# Altium schematic sheet bminator.SchDoc
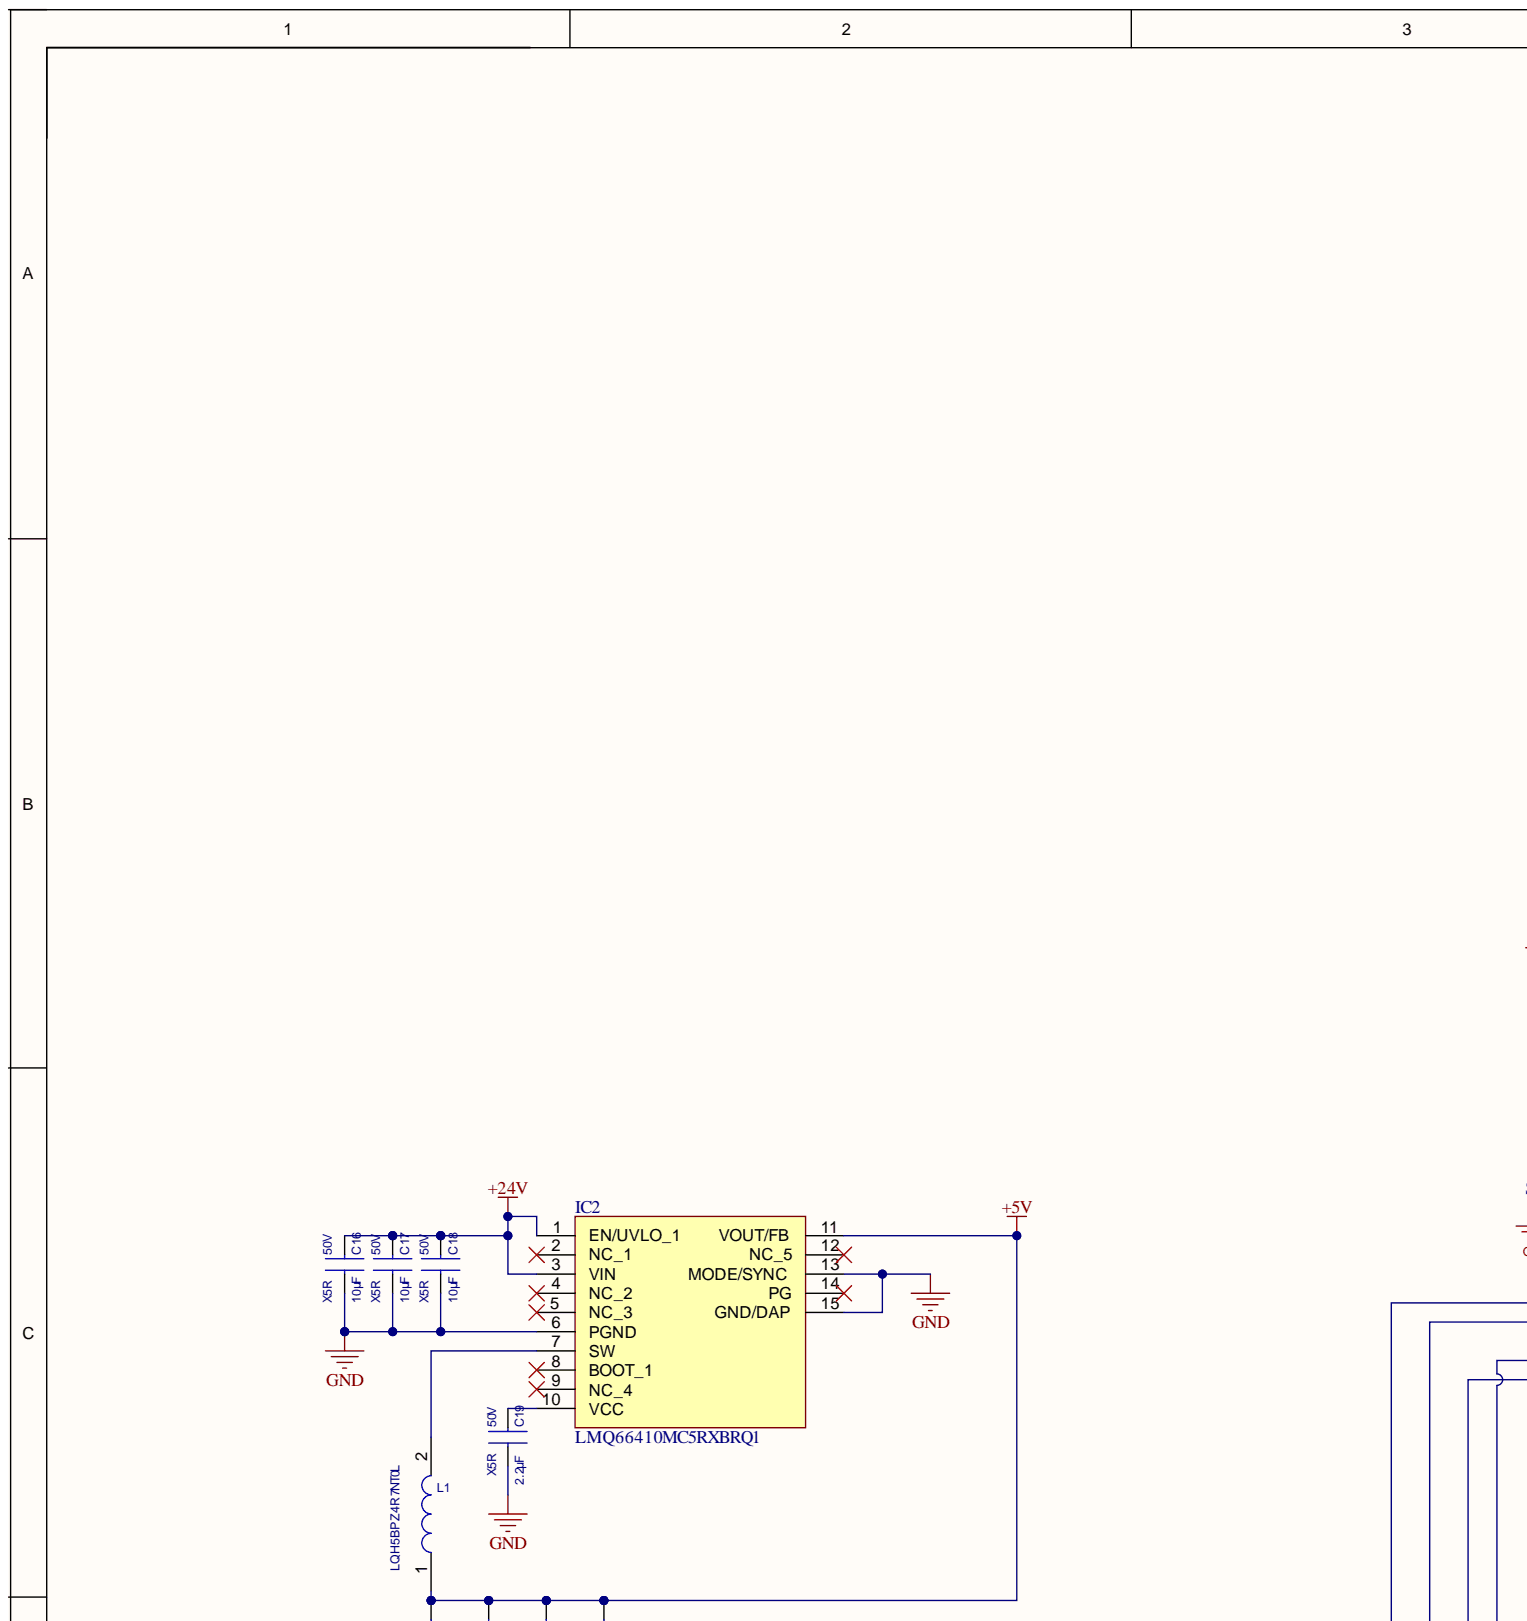
[diagram p0: bminator.SchDoc, top left]
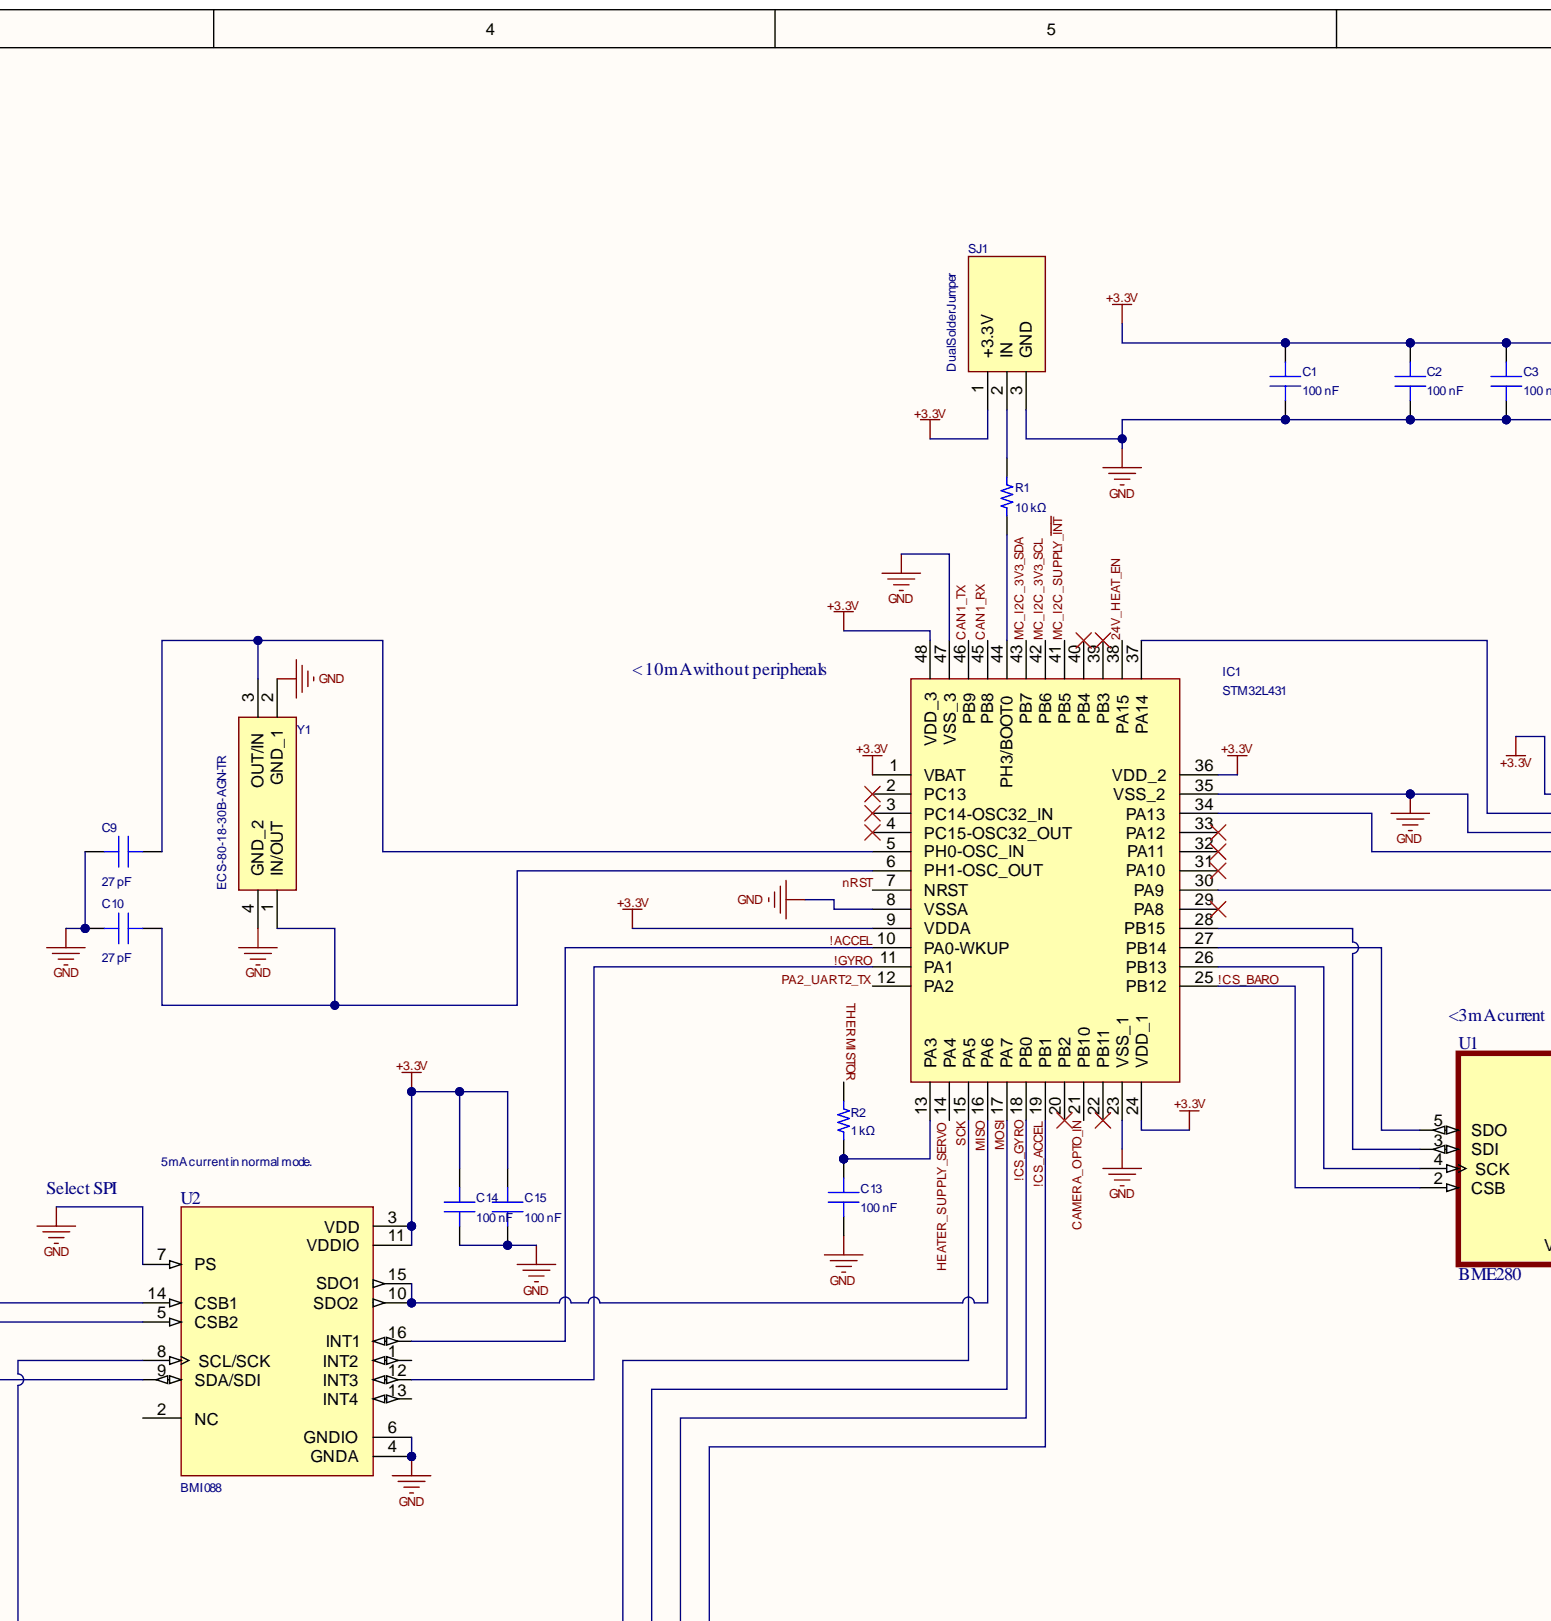
[diagram p1: bminator.SchDoc, top centre]
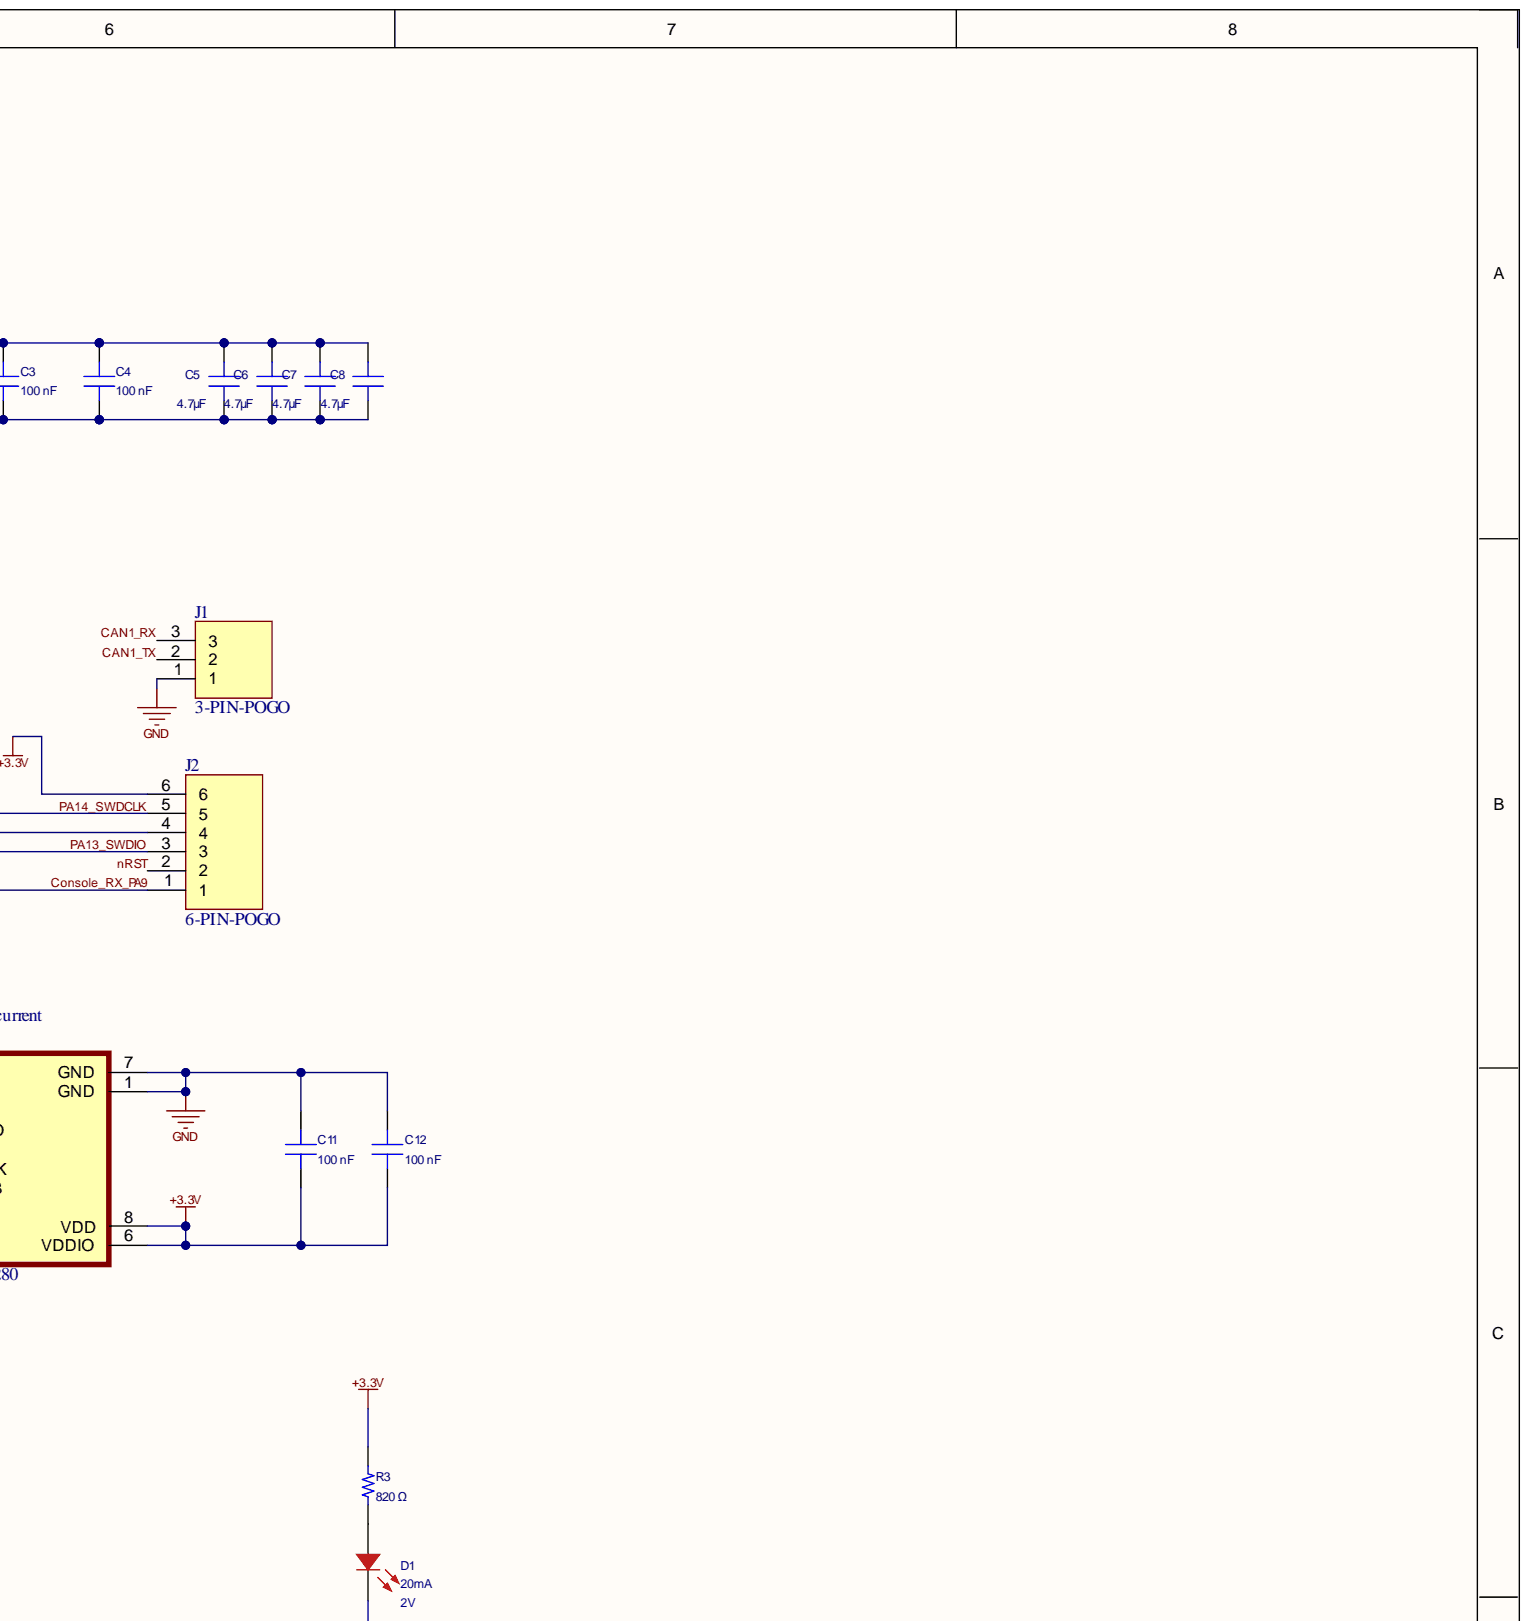
[diagram p2: bminator.SchDoc, top right]
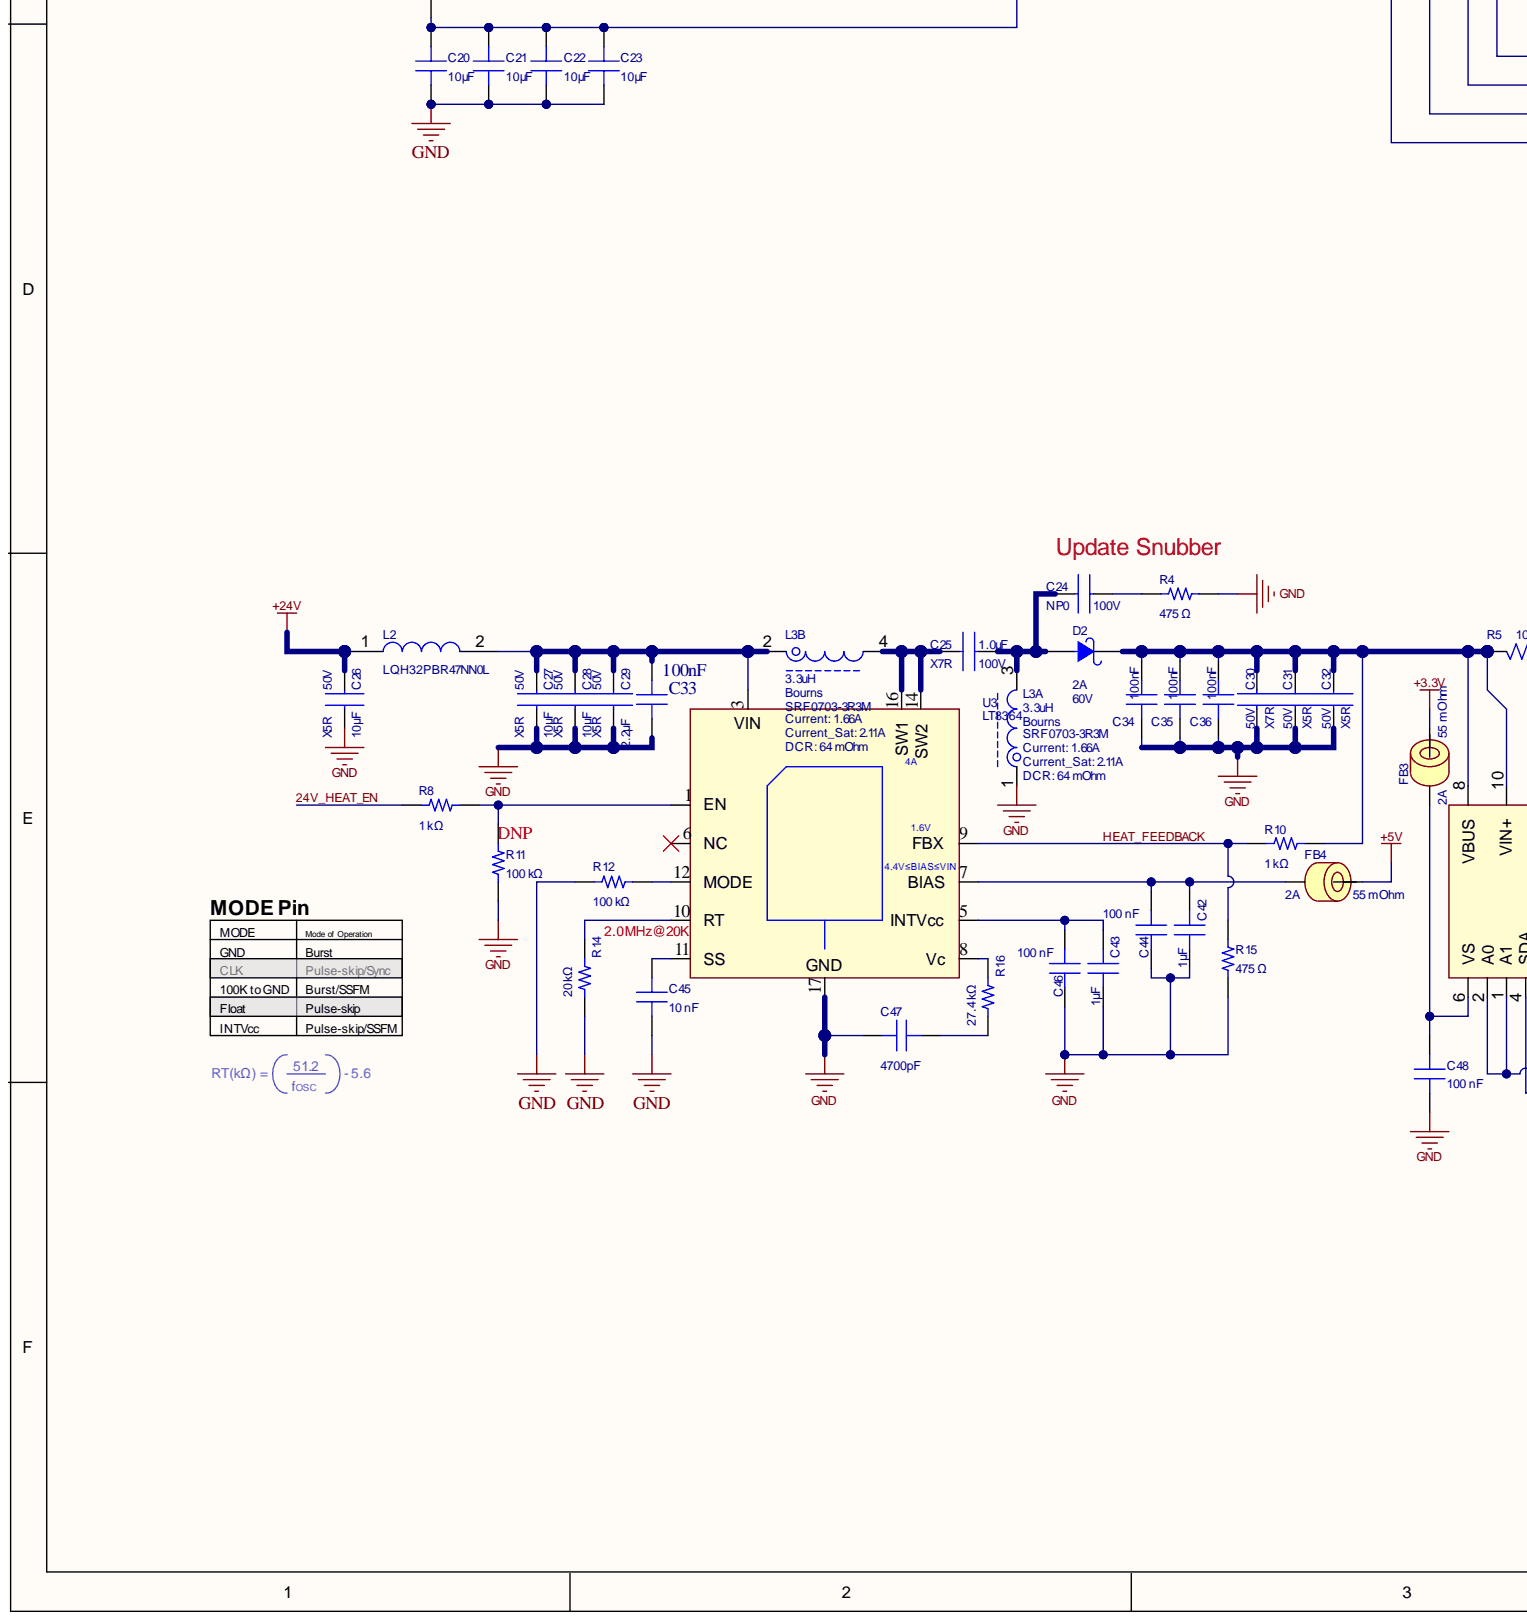
[diagram p3: bminator.SchDoc, bottom left]
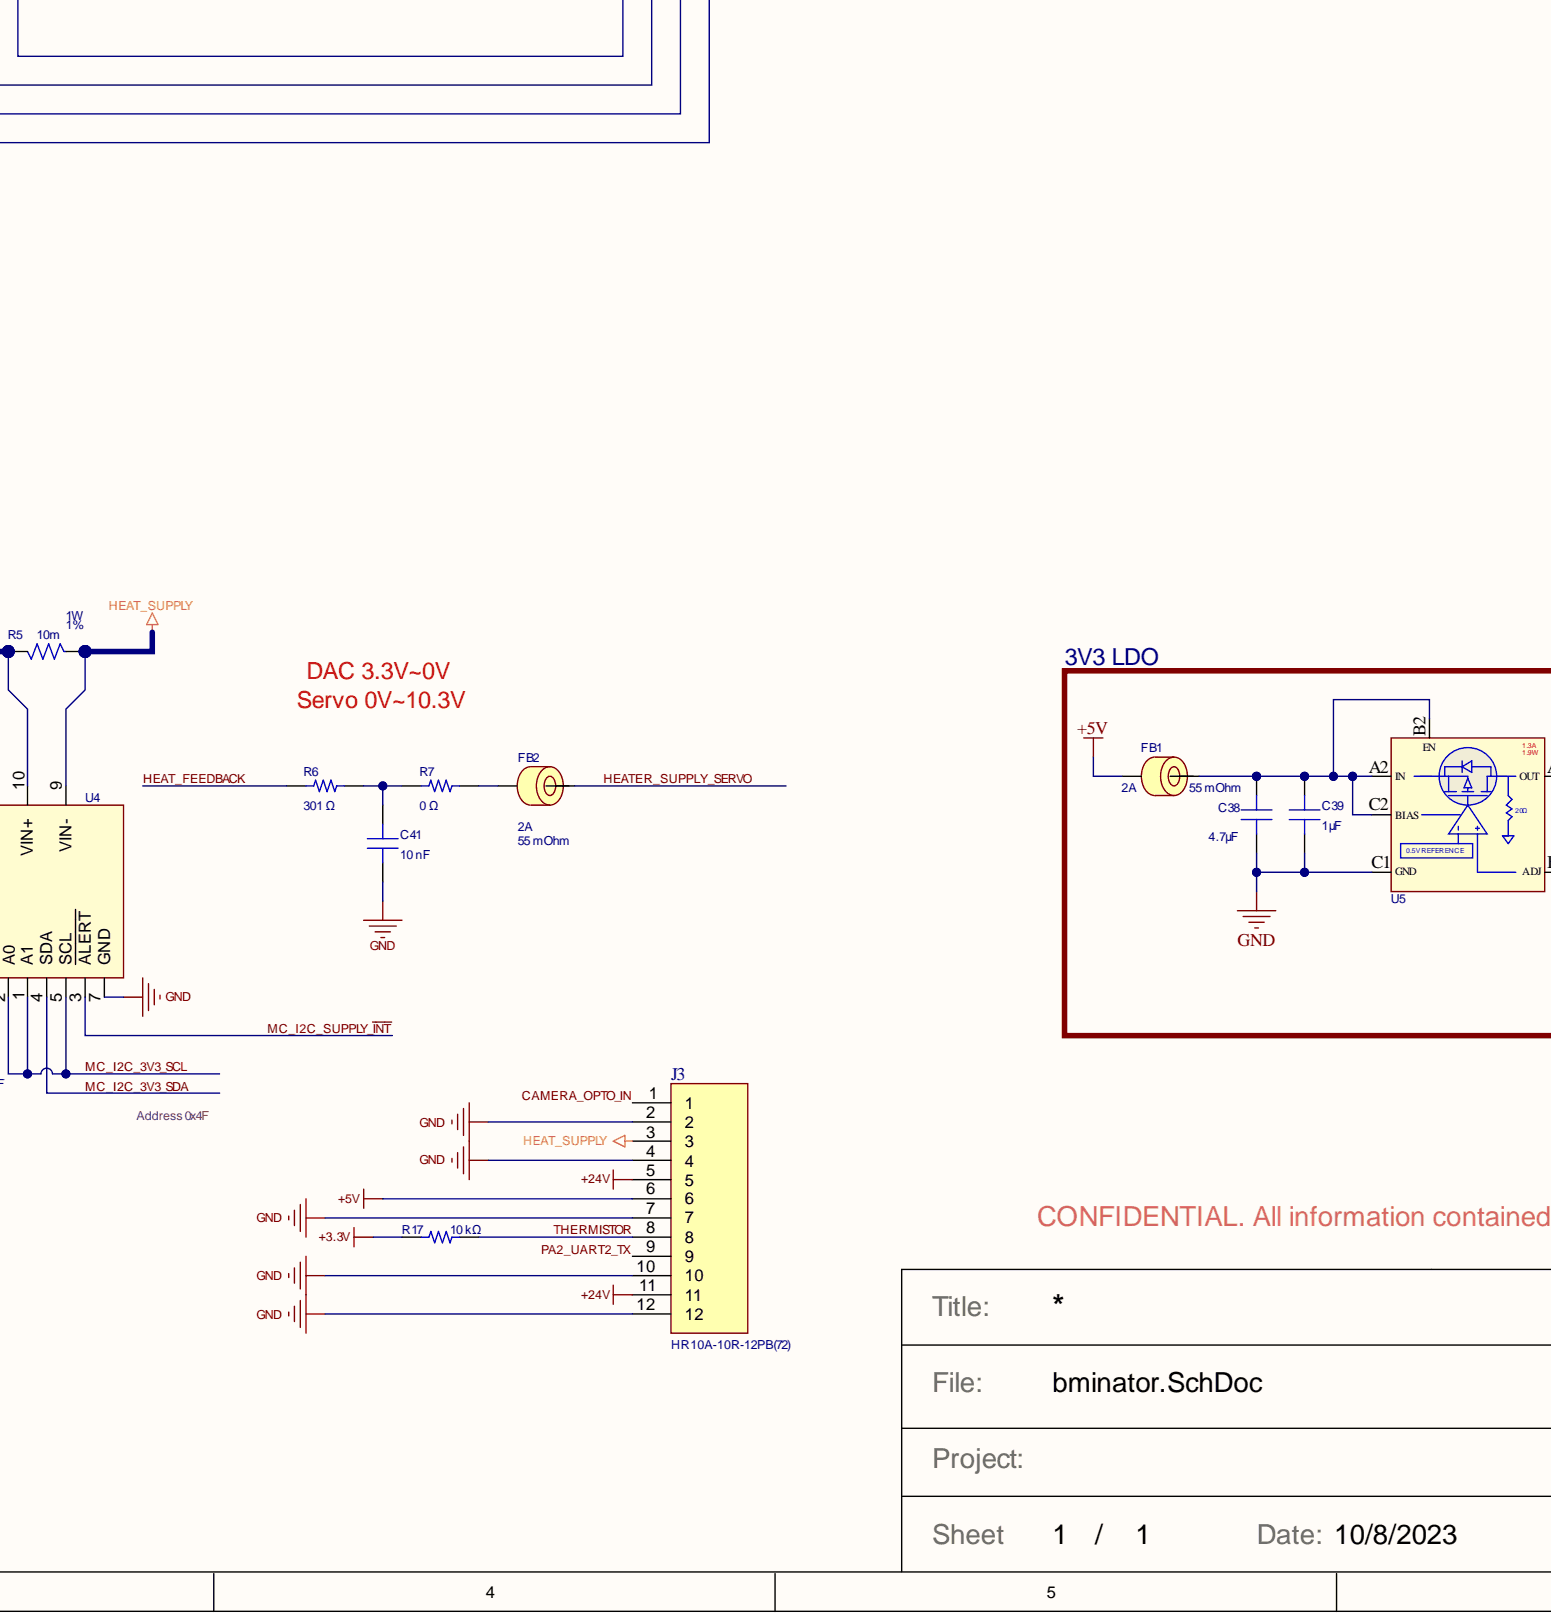
[diagram p4: bminator.SchDoc, bottom centre]
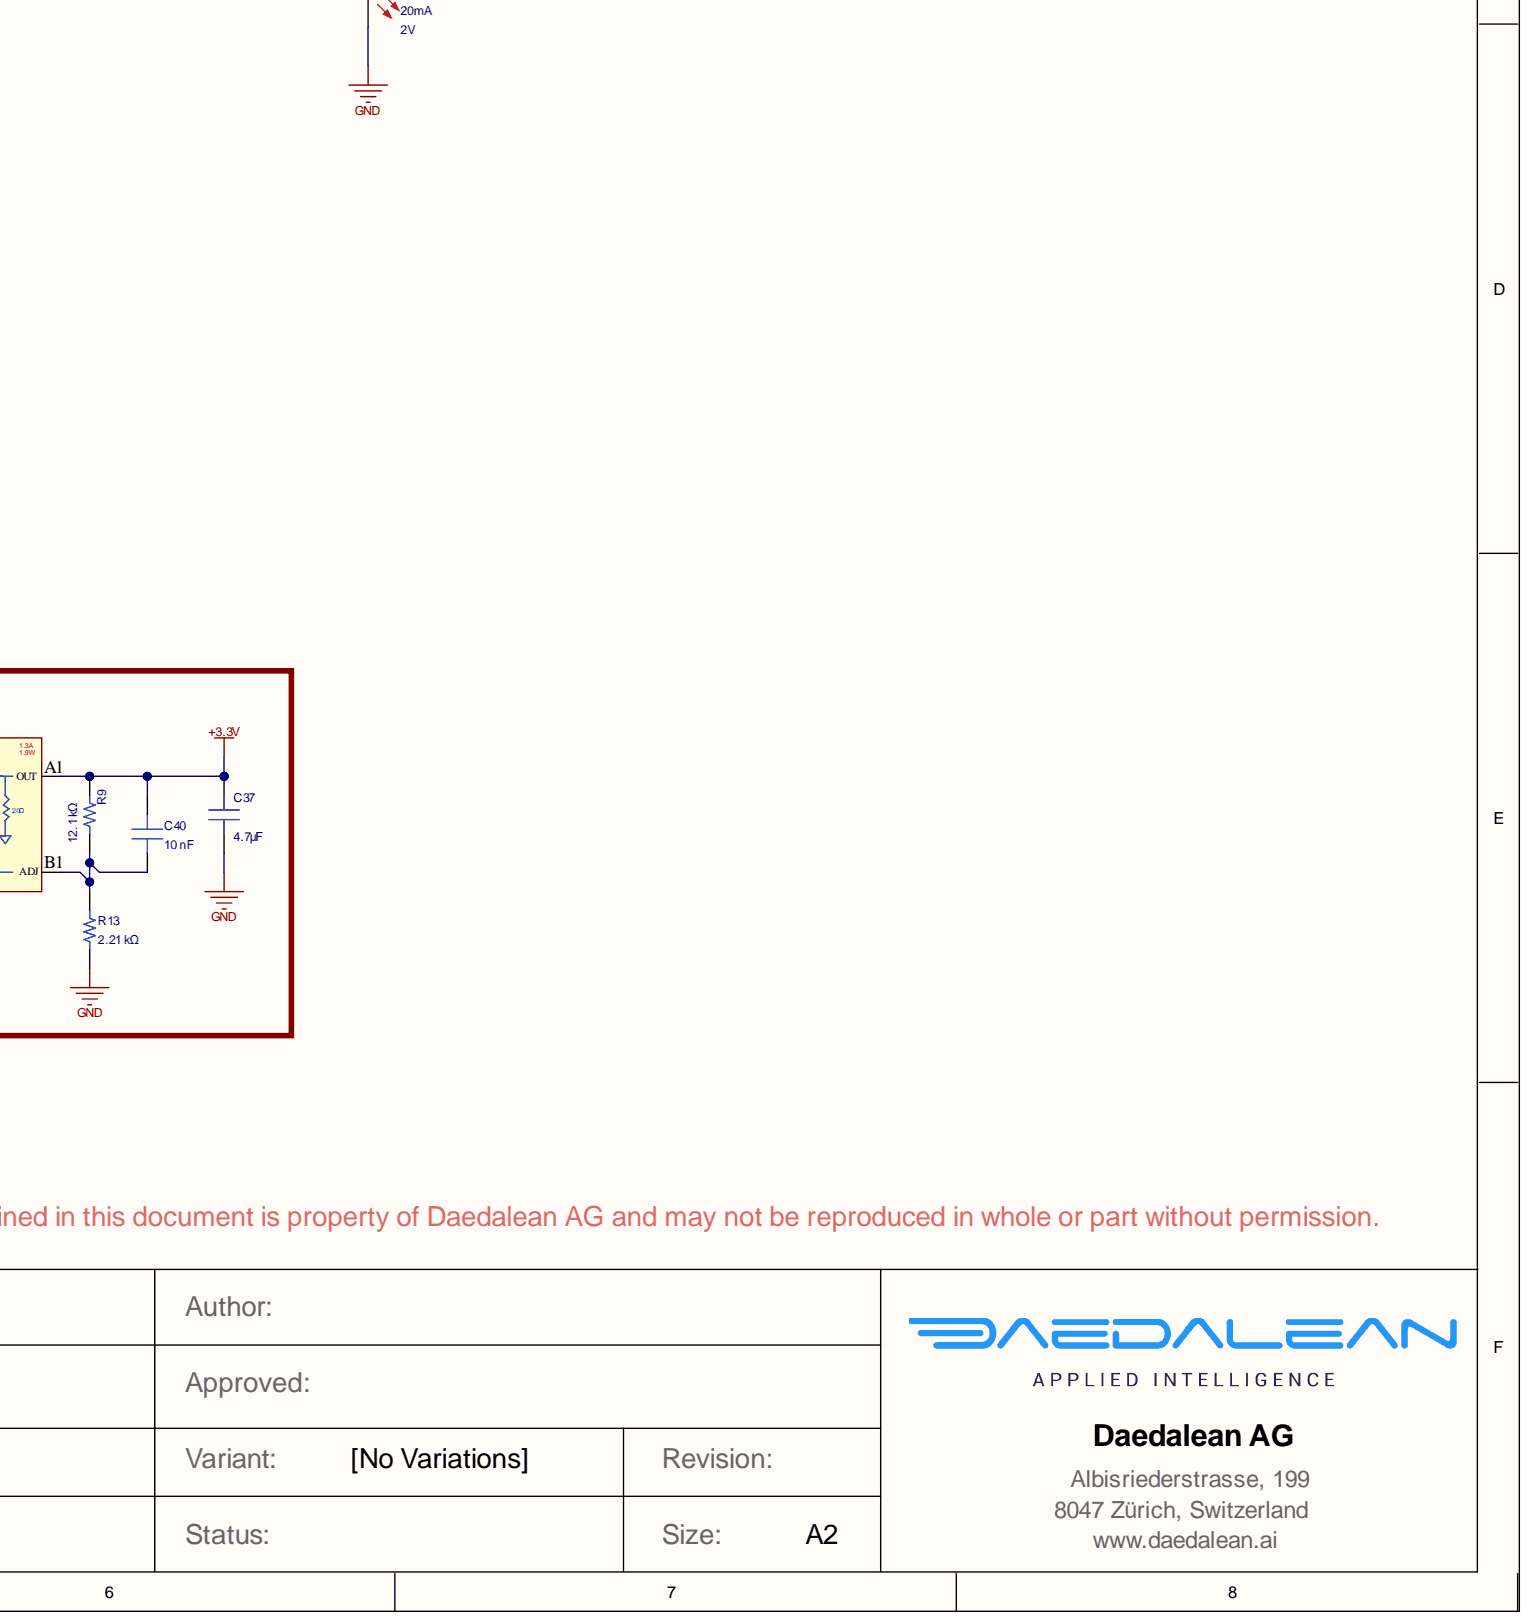
[diagram p5: bminator.SchDoc, bottom right]

## Parts
C1  value 100 nF  [CAP 0201_0603]  (hidden comment: GRT033C71C104KE01D)
C2  value 100 nF  [CAP 0201_0603]  (hidden comment: GRT033C71C104KE01D)
C3  value 100 nF  [CAP 0201_0603]  (hidden comment: GRT033C71C104KE01D)
C4  value 100 nF  [CAP 0201_0603]  (hidden comment: GRT033C71C104KE01D)
C5  4.7µF  [CAP 0402_1005]
C6  4.7µF  [CAP 0402_1005]
C7  4.7µF  [CAP 0402_1005]
C8  4.7µF  [CAP 0402_1005]
C9  value 27 pF  [CAP 0201_0603]  (hidden comment: GRT0335C1E270JA02D)
C10  value 27 pF  [CAP 0201_0603]  (hidden comment: GRT0335C1E270JA02D)
C11  value 100 nF  [CAP 0201_0603]  (hidden comment: GRT033C71C104KE01D)
C12  value 100 nF  [CAP 0201_0603]  (hidden comment: GRT033C71C104KE01D)
C13  value 100 nF  [CAP 0201_0603]  (hidden comment: GRT033C71C104KE01D)
C14  value 100 nF  [CAP 0201_0603]  (hidden comment: GRT033C71C104KE01D)
C15  value 100 nF  [CAP 0201_0603]  (hidden comment: GRT033C71C104KE01D)
C16  10µF  DIELECTRIC: X5R  VOLTAGE: 50V  [CAPC2013X140N]
C17  10µF  DIELECTRIC: X5R  VOLTAGE: 50V  [CAPC2013X140N]
C18  10µF  DIELECTRIC: X5R  VOLTAGE: 50V  [CAPC2013X140N]
C19  2.2µF  DIELECTRIC: X5R  VOLTAGE: 50V  [CAPC1608X95N]
C20  value 10µF  [CAP 0603_1608]  (hidden comment: GRT188R61C106KE13D)
C21  value 10µF  [CAP 0603_1608]  (hidden comment: GRT188R61C106KE13D)
C22  value 10µF  [CAP 0603_1608]  (hidden comment: GRT188R61C106KE13D)
C23  value 10µF  [CAP 0603_1608]  (hidden comment: GRT188R61C106KE13D)
C24  DIELECTRIC: NP0  VOLTAGE: 100V  [CAPC1608X95N]
C25  1.0µF  DIELECTRIC: X7R  VOLTAGE: 100V  [CAPC2013X140N]
C26  10µF  DIELECTRIC: X5R  VOLTAGE: 50V  [CAPC2013X140N]
C27  10µF  DIELECTRIC: X5R  VOLTAGE: 50V  [CAPC2013X140N]
C28  10µF  DIELECTRIC: X5R  VOLTAGE: 50V  [CAPC2013X140N]
C29  2.2µF  DIELECTRIC: X5R  VOLTAGE: 50V  [CAPC1608X95N]
C30  DIELECTRIC: X7R  VOLTAGE: 50V  [CAPC3225X279N]
C31  DIELECTRIC: X5R  VOLTAGE: 50V  [CAPC2013X140N]
C32  DIELECTRIC: X5R  VOLTAGE: 50V  [CAPC2013X140N]
C33  100nF  [CAP 0402_1005]
C34  100nF  [CAP 0402_1005]
C35  100nF  [CAP 0402_1005]
C36  100nF  Voltage - Rated: 50V  [CAP 0402_1005]
C37  4.7µF  [CAP 0402_1005]
C38  4.7µF  [CAP 0402_1005]
C39  1µF  [CAP 0402_1005]
C40  value 10 nF  [CAP 0201_0603]  (hidden comment: 02013C103MAT2A)
C41  value 10 nF  [CAP 0201_0603]  (hidden comment: 02013C103MAT2A)
C42  1µF  [CAP 0402_1005]
C43  1µF  [CAP 0402_1005]
C44  value 100 nF  [CAP 0201_0603]  (hidden comment: GRT033C71C104KE01D)
C45  value 10 nF  [CAP 0201_0603]  (hidden comment: 02013C103MAT2A)
C46  value 100 nF  [CAP 0201_0603]  (hidden comment: GRT033C71C104KE01D)
C47  4700pF  [CAP 0402_1005]
C48  value 100 nF  [CAP 0201_0603]  (hidden comment: GRT033C71C104KE01D)
D1  Forward Voltage: 2V  Test Current: 20mA  [LED 0402 RED]  (hidden comment: VLMS1500-GS08)
D2  Current: 2A  Voltage: 60V  [SODFL3517X110N]
FB1  Current: 2A  DCR: 55 mOhm  [TDK_MPZ1005-H]
FB2  Current: 2A  DCR: 55 mOhm  [TDK_MPZ1005-H]
FB3  Current: 2A  DCR: 55 mOhm  [TDK_MPZ1005-H]
FB4  Current: 2A  DCR: 55 mOhm  [TDK_MPZ1005-H]
IC1  STM32L431  [QFP50P900X900X160-48N]
IC2  LMQ66410MC5RXBRQ1  [LMQ66430R5RXBR]
J1  3-PIN-POGO  [3PINPOGO]
J2  6-PIN-POGO  [6PINPOGO]
J3  HR10A-10R-12PB(72)  [HRS_HR10A-10R-12PB(72)]
L1  LQH5BPZ4R7NT0L  [LQH5BPB100MT0L]
L2  LQH32PBR47NN0L  [LQH32CH100K53L]
L3  3.3uH  DCR: 64 mOhm  Manufacturer: Bourns  Manufacturer P/N: SRF0703-3R3M  [BOURNS_SRF0703]  (parts A,B)
R1  10 kΩ  [RES 0201_0603]
R2  1 kΩ  [RES 0201_0603]
R3  820 Ω  [RES 0402_1005]
R4  475 Ω  [RES 0402_1005]
R5  value 10m  TOLERANCE: 1%  Wattage: 1W  [RESC3216X84N]
R6  301 Ω  [RES 0402_1005]
R7  0 Ω  [RES 0402_1005]
R8  1 kΩ  [RES 0201_0603]
R9  12.1 kΩ  [RES 0201_0603]
R10  1 kΩ  [RES 0402_1005]
R11  100 kΩ  Resistance: DNP  [RES 0201_0603]
R12  100 kΩ  [RES 0201_0603]
R13  2.21 kΩ  [RES 0201_0603]
R14  20 kΩ  [RES 0201_0603]
R15  475 Ω  [RES 0402_1005]
R16  27.4 kΩ  [RES 0201_0603]
R17  10 kΩ  [RES 0201_0603]
SJ1  DualSolderJumper  [DualSolderJumper]
U1  BME280  [PSON65P250X250X100-8N]
U2  BMI088  [PQFN50P450X300X100-16N]
U3  LT8364  Current: 4A  [SOP50P490X110-13N]
U4  (no value)  [SOP50P490X110-10N]
U5  Current: 1.3A  Wattage: 1.9W  [BGA8C40P2X3_80X120X33]
Y1  ECS-80-18-30B-AGN-TR  [ECS801830BAGNTR]

## Nets
+3.3V: C1.2 C2.2 C3.2 C4.2 C5.2 C6.2 C7.2 C8.2 C11.1 C12.1 C14.2 C15.2 C37.2 C40.2 FB3.1 IC1.1(VBAT) IC1.9(VDDA) IC1.24(VDD_1) IC1.36(VDD_2) IC1.48(VDD_3) J2.6 R3.1 R9.2 R17.2 SJ1.1 U1.6(VDDIO) U1.8(VDD) U2.3(VDD) U2.11(VDDIO) U5.A1(OUT)
+5V: C20.2 C21.2 C22.2 C23.2 FB1.2 FB4.1 IC2.11(VOUT/FB) J3.6 L1.1
GND: C1.1 C2.1 C3.1 C4.1 C5.1 C6.1 C7.1 C8.1 C9.2 C10.2 C11.2 C12.2 C13.1 C14.1 C15.1 C16.2 C17.2 C18.2 C19.2 C20.1 C21.1 C22.1 C23.1 C26.2 C27.2 C28.2 C29.2 C30.2 C31.2 C32.2 C33.1 C34.1 C35.1 C36.1 C37.1 C38.1 C39.1 C41.1 C42.1 C43.1 C44.1 C45.1 C46.1 C47.1 C48.1 D1.K IC1.8(VSSA) IC1.23(VSS_1) IC1.35(VSS_2) IC1.47(VSS_3) IC2.6(PGND) IC2.13(MODE/SYNC) IC2.15(GND/DAP) J1.1 J2.4 J3.2 J3.4 J3.7 J3.10 J3.12 L3.1 R4.1 R11.1 R12.1 R13.1 R14.1 R15.1 SJ1.3 U1.1(GND) U1.7(GND) U2.4(GNDA) U2.6(GNDIO) U2.7(PS) U3.17 U4.7(GND) U5.C1(GND) Y1.2(GND_1) Y1.4(GND_2)
HEAT_SUPPLY: J3.3 R5.1 U4.9(VIN-)
24V_HEAT_EN: C16.1 C17.1 C18.1 C26.1 IC1.38(PA15) IC2.1(EN/UVLO_1) IC2.3(VIN) J3.5 J3.11 L2.1 R8.1
!ACCEL: IC1.10(PA0-WKUP) U2.16(INT1)
!CS_ACCEL: IC1.19(PB1) U2.14(CSB1)
!CS_BARO: IC1.25(PB12) U1.2(CSB)
!CS_GYRO: IC1.18(PB0) U2.5(CSB2)
!GYRO: IC1.11(PA1) U2.12(INT3)
CAMERA_OPTO_IN: IC1.21(PB10) J3.1
CAN1_RX: IC1.45(PB8) J1.3
CAN1_TX: IC1.46(PB9) J1.2
Console_RX_PA9: IC1.30(PA9) J2.1
HEAT_FEEDBACK: R6.1 R10.1 R15.2 U3.9(FBX)
HEATER_SUPPLY_SERVO: FB2.1 IC1.14(PA4)
MC_I2C_3V3_SCL: IC1.42(PB6) U4.1(A1) U4.2(A0) U4.5(SCL)
MC_I2C_3V3_SDA: IC1.43(PB7) U4.4(SDA)
MC_I2C_SUPPLY_~{INT}: IC1.41(PB5) U4.3(ALERT)
MISO: IC1.16(PA6) U2.10(SDO2) U2.15(SDO1)
MOSI: IC1.17(PA7) U2.9(SDA/SDI)
nRST: IC1.7(NRST) J2.2
PA2_UART2_TX: IC1.12(PA2) J3.9
PA13_SWDIO: IC1.34(PA13) J2.3
PA14_SWDCLK: IC1.37(PA14) J2.5
SCK: IC1.15(PA5) U2.8(SCL/SCK)
THERMISTOR: J3.8 R2.2 R17.1
NetC9_1: C9.1 IC1.5(PH0-OSC_IN) Y1.3(OUT/IN)
NetC10_1: C10.1 IC1.6(PH1-OSC_OUT) Y1.1(IN/OUT)
NetC13_2: C13.2 IC1.13(PA3) R2.1
NetC19_1: C19.1 IC2.10(VCC)
NetC24_1: C24.1 C25.2 D2.A L3.3
NetC24_2: C24.2 R4.2
NetC25_1: C25.1 L3.4 U3.14 U3.16(SW1)
NetC27_1: C27.1 C28.1 C29.1 C33.2 L2.2 L3.2 U3.3
NetC30_1: C30.1 C31.1 C32.1 C34.2 C35.2 C36.2 D2.C R5.2 R10.2 U4.8(VBUS) U4.10(VIN+)
NetC38_2: C38.2 C39.2 FB1.1 U5.A2(IN) U5.B2(EN) U5.C2(BIAS)
NetC40_1: C40.1 R9.1 R13.2 U5.B1(ADJ)
NetC41_2: C41.2 R6.2 R7.1
NetC42_2: C42.2 C44.2 FB4.2 U3.7(BIAS)
NetC43_2: C43.2 C46.2 U3.5(INTVcc)
NetC45_2: C45.2 U3.11(SS)
NetC47_2: C47.2 R16.1
NetC48_2: C48.2 FB3.2 U4.6(VS)
NetD1_A: D1.A R3.2
NetFB2_2: FB2.2 R7.2
NetIC1_26: IC1.26(PB13) U1.4(SCK)
NetIC1_27: IC1.27(PB14) U1.5(SDO)
NetIC1_28: IC1.28(PB15) U1.3(SDI)
NetIC1_44: IC1.44(PH3/BOOT0) R1.1
NetIC2_7: IC2.7(SW) L1.2
NetR1_2: R1.2 SJ1.2
NetR8_2: R8.2 R11.2 U3.1(EN)
NetR12_2: R12.2 U3.12(MODE)
NetR14_2: R14.2 U3.10(RT)
NetR16_2: R16.2 U3.8(Vc)

Not connected: IC1 2(PC13) 3(PC14-OSC32_IN) 4(PC15-OSC32_OUT) 20(PB2) 22(PB11) 29(PA8) 31(PA10) 32(PA11) 33(PA12) 39(PB3) 40(PB4); IC2 2(NC_1) 4(NC_2) 5(NC_3) 8(BOOT_1) 9(NC_4) 12(NC_5) 14(PG); U2 1(INT2) 2(NC) 13(INT4); U3 6(NC)
